# Altium schematic sheet TOP.SchDoc(TOP)
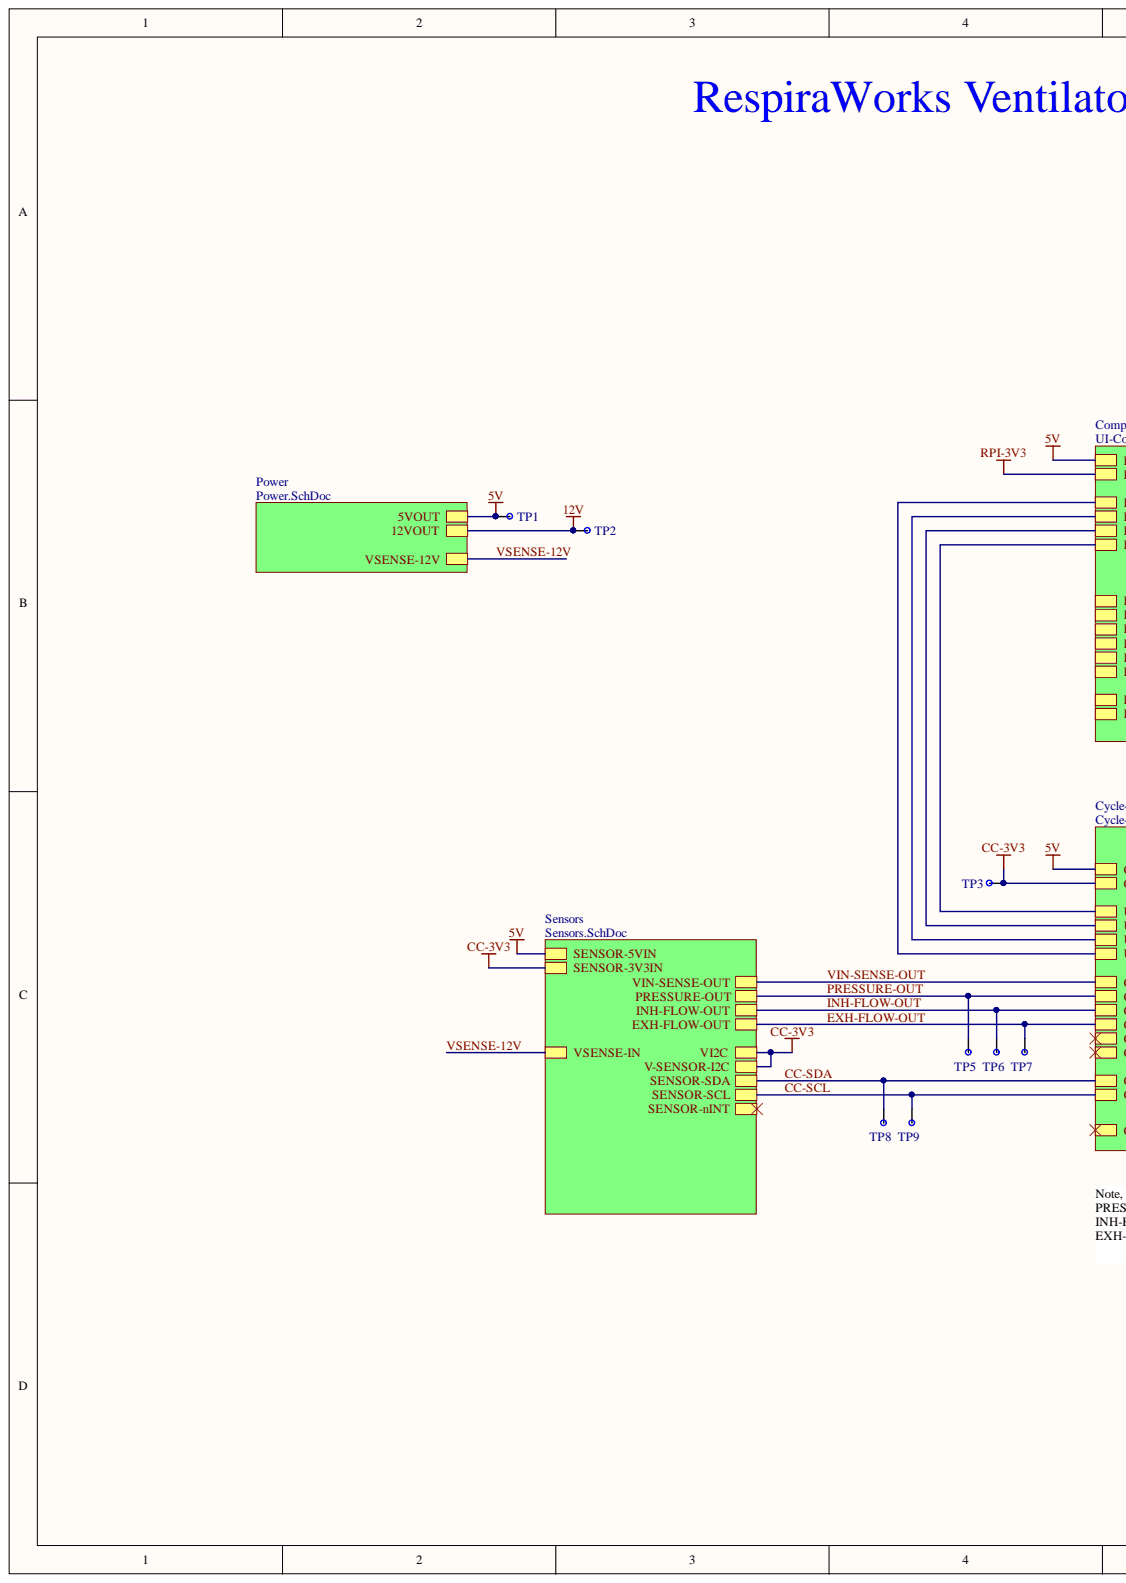
[diagram p0: TOP.SchDoc, left]
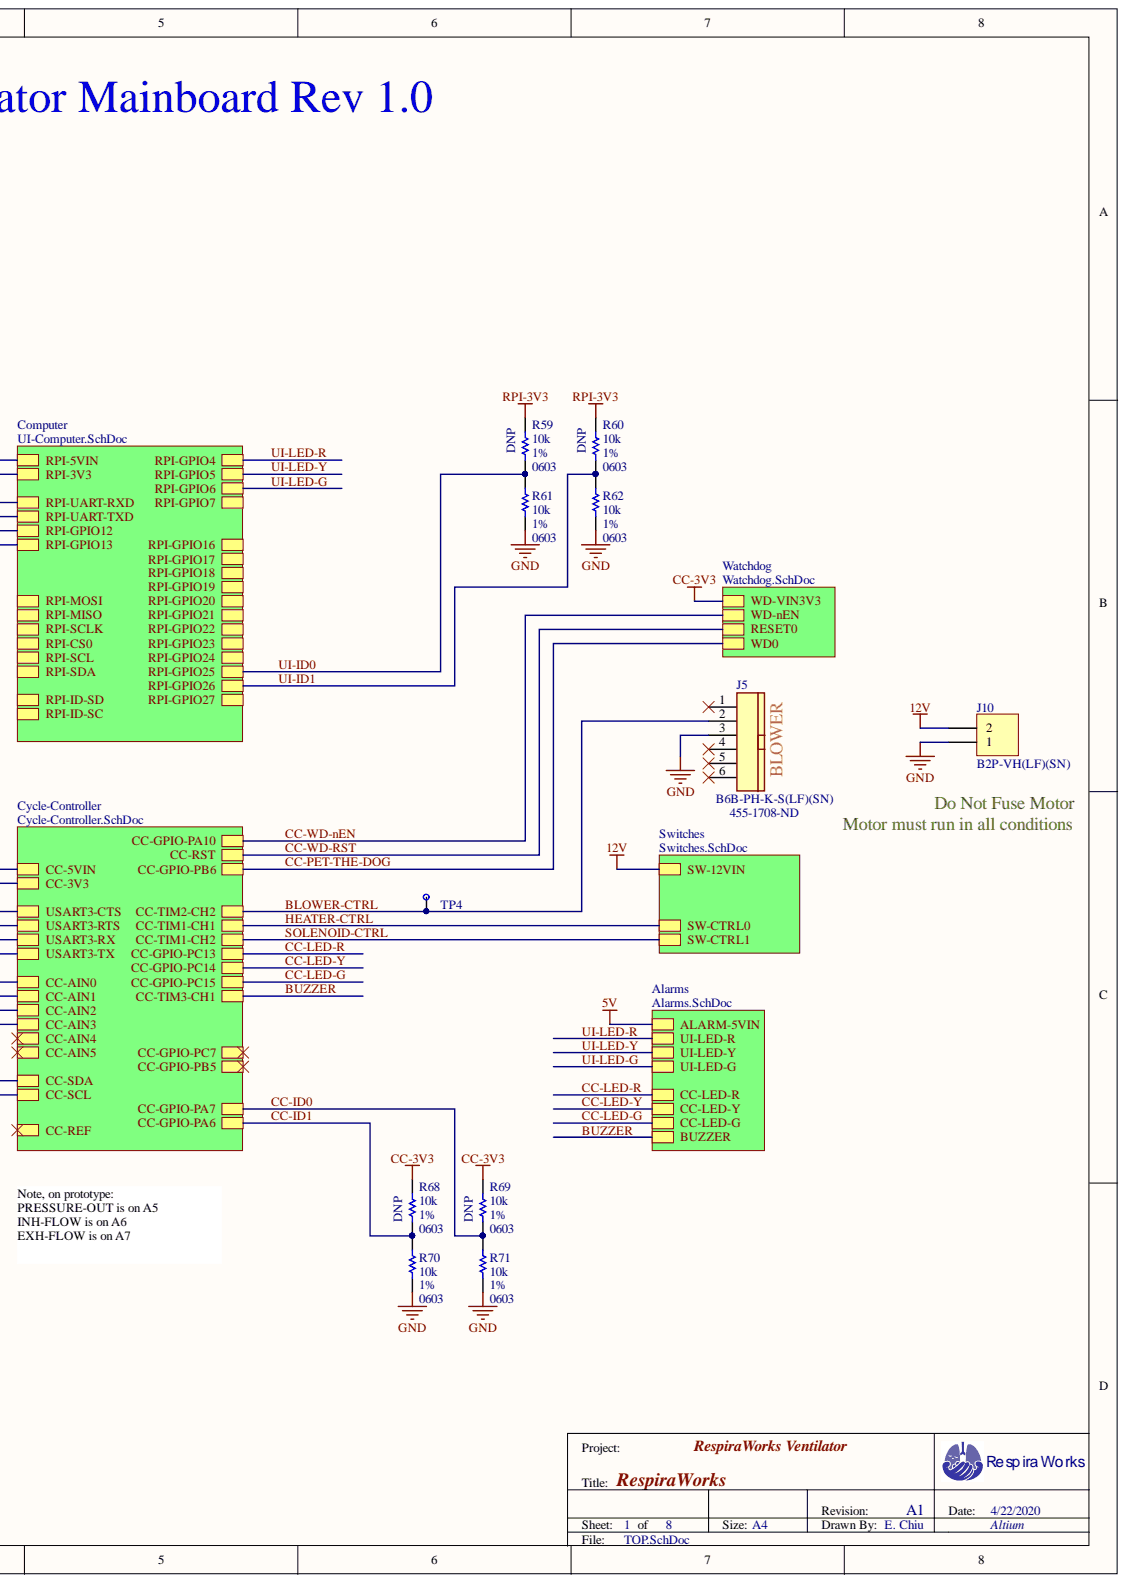
[diagram p1: TOP.SchDoc, right]

## Parts
J5  B6B-PH-K-S(LF)(SN)  Supplier Part Number 1: 455-1708-ND  [JST_B6B-XH-AM]
J10  B2P-VH(LF)(SN)  [B2P-VH(LF)(SN)]
R59  value 10k  Tolerance: 1%  PCB Package: 0603  Do Not Populate: DNP  [RES0603]  (hidden comment: RES 0603)
R60  value 10k  Tolerance: 1%  PCB Package: 0603  Do Not Populate: DNP  [RES0603]  (hidden comment: RES 0603)
R61  value 10k  Tolerance: 1%  PCB Package: 0603  [RES0603]  (hidden comment: RES 0603)
R62  value 10k  Tolerance: 1%  PCB Package: 0603  [RES0603]  (hidden comment: RES 0603)
R68  value 10k  Tolerance: 1%  PCB Package: 0603  Do Not Populate: DNP  [RES0603]  (hidden comment: RES 0603)
R69  value 10k  Tolerance: 1%  PCB Package: 0603  Do Not Populate: DNP  [RES0603]  (hidden comment: RES 0603)
R70  value 10k  Tolerance: 1%  PCB Package: 0603  [RES0603]  (hidden comment: RES 0603)
R71  value 10k  Tolerance: 1%  PCB Package: 0603  [RES0603]  (hidden comment: RES 0603)
TP1  TP-SMT  [TP-5015]  (value not drawn)
TP2  TP-SMT  [TP-5015]  (value not drawn)
TP3  TP-SMT  [TP-5015]  (value not drawn)
TP4  TP-SMT  [TP-5015]  (value not drawn)
TP5  TP-SMT  [TP-5015]  (value not drawn)
TP6  TP-SMT  [TP-5015]  (value not drawn)
TP7  TP-SMT  [TP-5015]  (value not drawn)
TP8  TP-SMT  [TP-5015]  (value not drawn)
TP9  TP-SMT  [TP-5015]  (value not drawn)

## Nets
5V: TP1.1
12V: J10.2 TP2.1
CC-3V3: R68.2 R69.2 TP3.1
GND: J5.3 J10.1 R61.1 R62.1 R70.1 R71.1
RPI-3V3: R59.2 R60.2
BLOWER-CTRL: J5.2 TP4.1
CC-ID0: R69.1 R71.2
CC-ID1: R68.1 R70.2
CC-SCL: TP9.1
CC-SDA: TP8.1
EXH-FLOW-OUT: TP7.1
INH-FLOW-OUT: TP6.1
PRESSURE-OUT: TP5.1
UI-ID0: R59.1 R61.2
UI-ID1: R60.1 R62.2
NetJ5_1: J5.1
NetJ5_4: J5.4
NetJ5_5: J5.5
NetJ5_6: J5.6
BUZZER:
CC-AIN4:
CC-AIN5:
CC-GPIO-PB5:
CC-GPIO-PC7:
CC-LED-G:
CC-LED-R:
CC-LED-Y:
CC-PET-THE-DOG:
CC-REF:
CC-WD-nEN:
CC-WD-RST:
HEATER-CTRL:
RPI-CS0:
RPI-GPIO7:
RPI-GPIO12:
RPI-GPIO13:
RPI-GPIO16:
RPI-GPIO17:
RPI-GPIO18:
RPI-GPIO19:
RPI-GPIO20:
RPI-GPIO21:
RPI-GPIO22:
RPI-GPIO23:
RPI-GPIO24:
RPI-GPIO27:
RPI-ID-SC:
RPI-ID-SD:
RPI-MISO:
RPI-MOSI:
RPI-SCL:
RPI-SCLK:
RPI-SDA:
RPI-UART-RXD:
RPI-UART-TXD:
SENSOR-nINT:
SOLENOID-CTRL:
UI-LED-G:
UI-LED-R:
UI-LED-Y:
VIN-SENSE-OUT:
VSENSE-12V:

## Library data of the parts
J5 = B6B-PH-K-S(LF)(SN): CONN HEADER PH TOP 6POS 2MM; Manufacturer: JST Sales America Inc.; Pitch: 0.079" (2.00mm); Number of Positions Loaded: All; Number of Positions: 6; Connector Type: Header, Shrouded; Termination: Press-Fit, Solder; Supplier 1: Digi-Key; Stock 1: 30111; Row Spacing: -; RoHS: RoHS Compliant; Pricing 1: 1=0.33, 10=0.313, 100=0.2194, 500=0.18808, 1000=0.15674, 5000=0.13435, 10000=0.12539 (USD); Part Status: Active; Packaging: Bulk; Number of Rows: 1; Mounting Type: Through Hole; Manufacturer Part Number: B6B-PH-K-S(LF)(SN); Library Name: JST XH.SchLib; Features: -; Fastening Type: Detent Lock; Contact Type: Male Pin; Contact Mating Length: 0.130" (3.30mm); Contact Finish Thickness: -; Contact Finish: Tin; ComponentLink1URL: http://www.jst-mfg.com/product/pdf/eng/ePH.pdf; ComponentLink1Description: http://www.jst-mfg.com/product/pdf/eng/ePH.pdf; Component Kind: Standard; Color: Natural; Category: Connectors, Interconnects
J10 = B2P-VH(LF)(SN): JST-VH HEADER VERT 2POS 3.96MM; Manufacturer: JST; Manufacturer P/N: B2P-VH(LF)(SN); Library Name: JST.SchLib; Component Kind: Standard
R59-R62 R68-R71 = RES 0603: Manufacturer P/N: ERJ-3EKF1002V; Manufacturer: Panasonic; Library Name: generic.SchLib; Description: Res 0603; Component Kind: Standard
TP1-TP9 = TP-SMT: Keystone 5015 SMT Mini Testpoint; Tolerance: 5015; PCB Package: TP-5015; Manufacturer: Keystone; Library Name: generic.SchLib; Component Kind: Standard
